# Altium schematic sheet mArm-shield.SchDoc
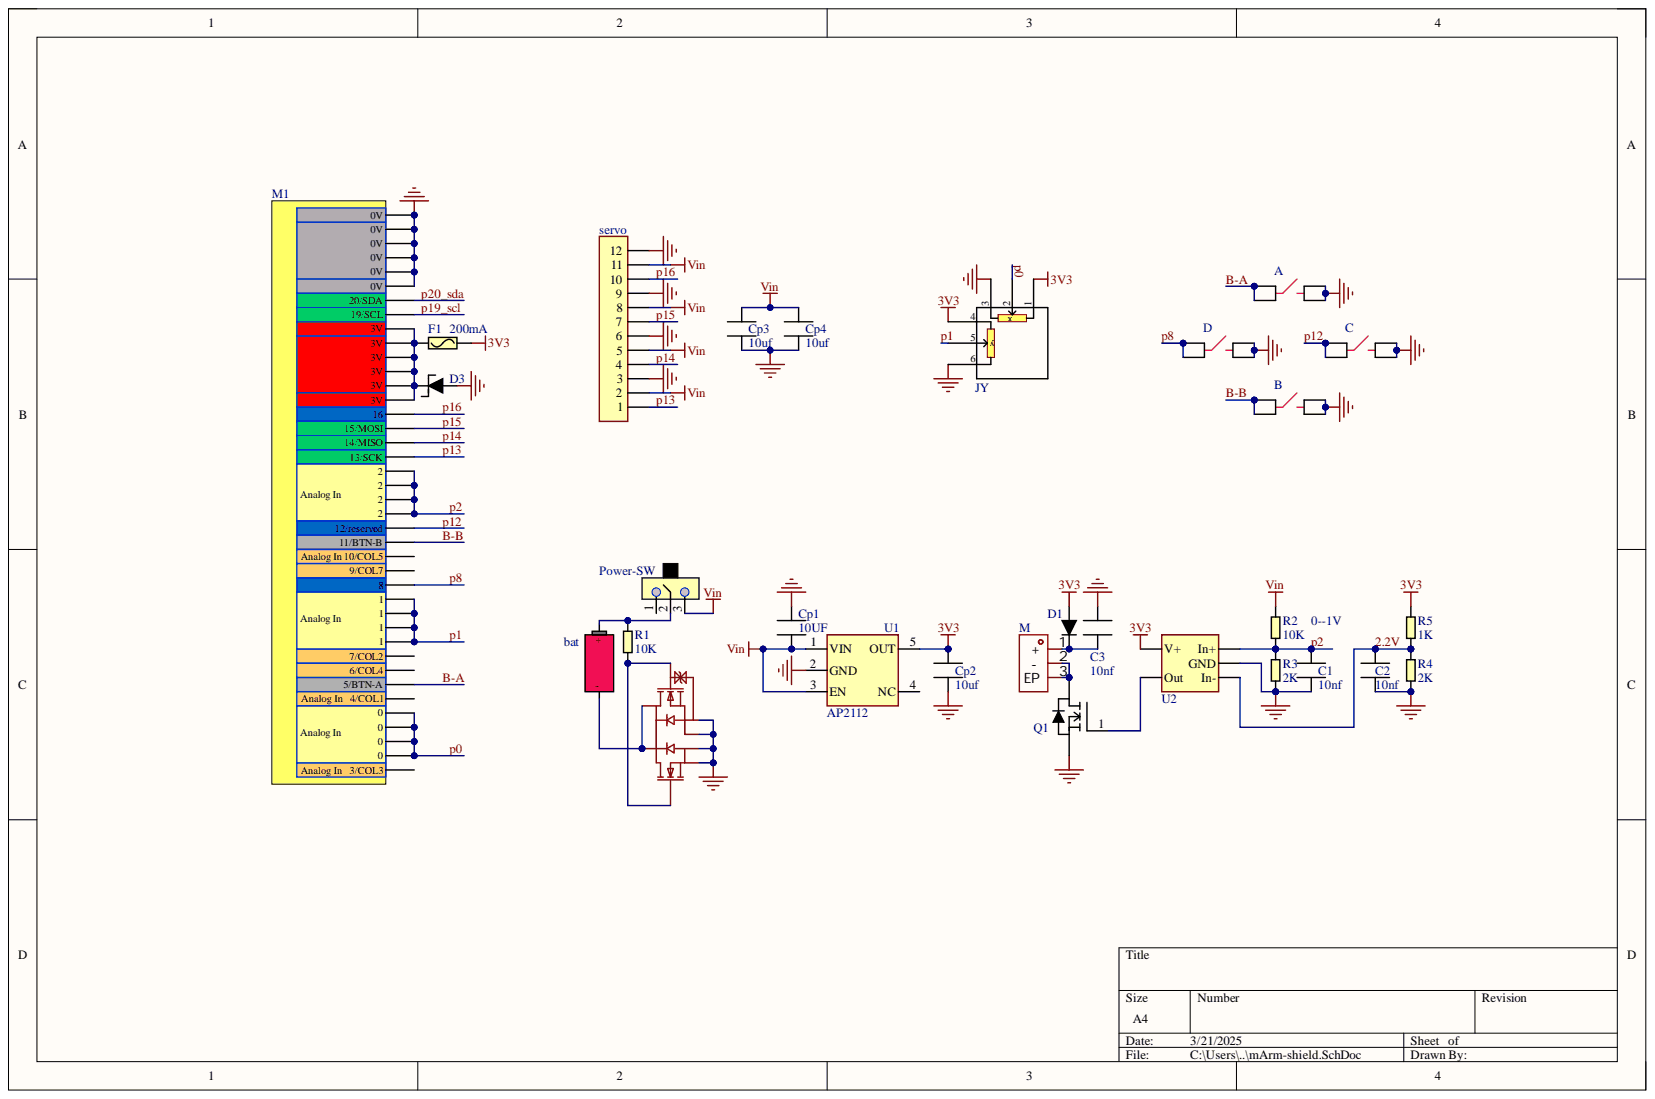
[diagram p0: mArm-shield.SchDoc]

## Parts
A  KEY-4P  [key-4p]  (value not drawn)
B  KEY-4P  [key-4p]  (value not drawn)
bat  BAT  [18650_1cell]  (value not drawn)
C  KEY-4P  [key-4p]  (value not drawn)
C1  10nf  [RES-0603]
C2  10nf  [RES-0603]
C3  10nf  [RES-0603]
Cp1  10UF  [0805]
Cp2  10uf  [0805]
Cp3  10uf  [0805]
Cp4  10uf  [0805]
D  KEY-4P  [key-4p]  (value not drawn)
D1  1N5819W  [SOD-323]  (value not drawn)
D3  Diode-W  [SOD-323]  (value not drawn)
F1  200mA  [0603]
JY  PSP摇杆  [PSP摇杆]  (value not drawn)
M  震动马达  [震动马达]  (value not drawn)
M1  Microbit-40p  [micro:bit slot]  (value not drawn)
Power-SW  SS-12F21G3  [SS-12F23VGx]  (value not drawn)
Q1  N7002  [SOT-23_L]  (value not drawn)
R1  10K  [0603]
R2  10K  [0603]
R3  2K  [0603]
R4  2K  [0603]
R5  1K  [0603]
servo  Connector-12P  [1X12x2.54mm]  (value not drawn)
U1  AP2112  [SOT-23-5]
U2  LM321MF  [SOT-23-DBV]  (value not drawn)
U4  AO8814-HXY  [TSSOP-8_L4.4-W3.0-P0.65-LS6.4-BL]  (value not drawn)

## Nets
3V3: Cp2.2 D1.1 F1.2 JY.1 JY.4 R5.1 U1.5(OUT) U2.5(V+)
GND: A.3 A.4 B.3 B.4 C.3 C.4 C1.1 C2.1 C3.1 Cp1.2 Cp2.1 Cp3.1 Cp4.1 D.3 D.4 D3.1 JY.3 JY.6 M1.1(0V) M1.2(0V) M1.3(0V) M1.4(0V) M1.5(0V) M1.6(0V) Q1.2 R3.2 R4.2 servo.3 servo.6 servo.9 servo.12 U1.2(GND) U2.2(GND) U4.2 U4.3 U4.6 U4.7
Vin: Cp1.1 Cp3.2 Cp4.2 Power-SW.3 R2.1 servo.2 servo.5 servo.8 servo.11 U1.1(VIN) U1.3(EN)
2.2V: C2.2 R4.1 R5.2 U2.3(In-)
B-A: A.1 A.2 M1.34(5/BTN-A)
B-B: B.1 B.2 M1.24(11/BTN-B)
p0: JY.2(x) M1.36 M1.37 M1.38 M1.39
p1: JY.5(y) M1.28 M1.29 M1.30 M1.31
p2: C1.2 M1.19 M1.20 M1.21 M1.22 R2.2 R3.1 U2.1(In+)
p8: D.1 D.2 M1.27
p12: C.1 C.2 M1.23(12/reserved)
p13: M1.18(13/SCK) servo.1
p14: M1.17(14/MISO) servo.4
p15: M1.16(15/MOSI) servo.7
p16: M1.15 servo.10
p19_scl: M1.8(19/SCL)
p20_sda: M1.7(20/SDA)
Netbat_1: bat.1 U4.1 U4.8
Netbat_2: bat.2 Power-SW.2 R1.1
NetC3_2: C3.2 D1.2 M.1
NetD3_2: D3.2 F1.1 M1.9(3V) M1.10(3V) M1.11(3V) M1.12(3V) M1.13(3V) M1.14(3V)
NetM_2: M.2 M.3 Q1.3
NetQ1_1: Q1.1 U2.4(Out)
NetR1_2: R1.2 U4.4 U4.5

Not connected: M1 25(Analog_In_10/COL5) 26(9/COL7) 32(7/COL2) 33(6/COL4) 35(4/COL1) 40(3/COL3); Power-SW 1; U1 4(NC)

## Library data of the parts
C1-C3 = CAP-0603: Component Kind: Standard; Library Name: Altium_Zizhi_Lib.SchLib
Cp1 = CAP-1210: Component Kind: Standard; Library Name: Altium_Zizhi_Lib.SchLib
Cp2-Cp4 = CAP-0805: Component Kind: Standard; Library Name: Altium_Zizhi_Lib.SchLib
D1 = Diode: Component Kind: Standard; Library Name: Altium_Zizhi_Lib.SchLib
F1 = FUSE: Component Kind: Standard; Library Name: Altium_Zizhi_Lib.SchLib
Power-SW = switch_3P: Component Kind: Standard; Library Name: Altium_Zizhi_Lib.SchLib
R1-R5 = Resistor: Component Kind: Standard; Library Name: Altium_Zizhi_Lib.SchLib
U4 = AO8814-HXY: Manufacturer: HXY MOSFET(华轩阳电子); Add into BOM: yes; Continuous Drain Current (Id): 7.5A; Drain Source On Resistance (RDS(on)@Vgs,Id): 13mΩ@4.5V,3.5A; Drain Source Voltage (Vdss): 20V; Power Dissipation (Pd): 1.25W; Comment_1: AO8814-HXY; Component Kind: Standard; Convert to PCB: yes; Datasheet: https://atta.szlcsc.com/upload/public/pdf/source/20231222/43B68DCF489C531DF56929DC8616AD30.pdf; Device: AO8814-HXY; JLCPCB Part Class: Extended Part; LCSC Part Name: N沟道 耐压:20V 电流:7.5A; Manufacturer Part: AO8814-HXY; Supplier: LCSC; Supplier Footprint: TSSOP-8; Supplier Part: C19723843; Symbol: AO8814-HXY; Type: N沟道
A B C D = KEY-4P: Component Kind: Standard; Library Name: Altium_Zizhi_Lib.SchLib
bat = BAT: Component Kind: Standard; Library Name: Altium_Zizhi_Lib.SchLib
D3 = Diode-W: Component Kind: Standard; Library Name: Altium_Zizhi_Lib.SchLib
JY = PSP摇杆: Component Kind: Standard; Library Name: Altium_Zizhi_Lib.SchLib
M = 震动马达: Component Kind: Standard; Library Name: Altium_Zizhi_Lib.SchLib
M1 = Microbit-40p: Component Kind: Standard; Library Name: Altium_Zizhi_Lib.SchLib
Q1 = N7002: Component Kind: Standard; Library Name: Altium_Zizhi_Lib.SchLib
servo = Connector-12P: Component Kind: Standard; Library Name: Altium_Zizhi_Lib.SchLib
U1 = AP2112: Component Kind: Standard; Library Name: Altium_Zizhi_Lib.SchLib
U2 = LM321MF: Component Kind: Standard; Library Name: Altium_Zizhi_Lib.SchLib
